# Altium schematic sheet Wifi.SchDoc
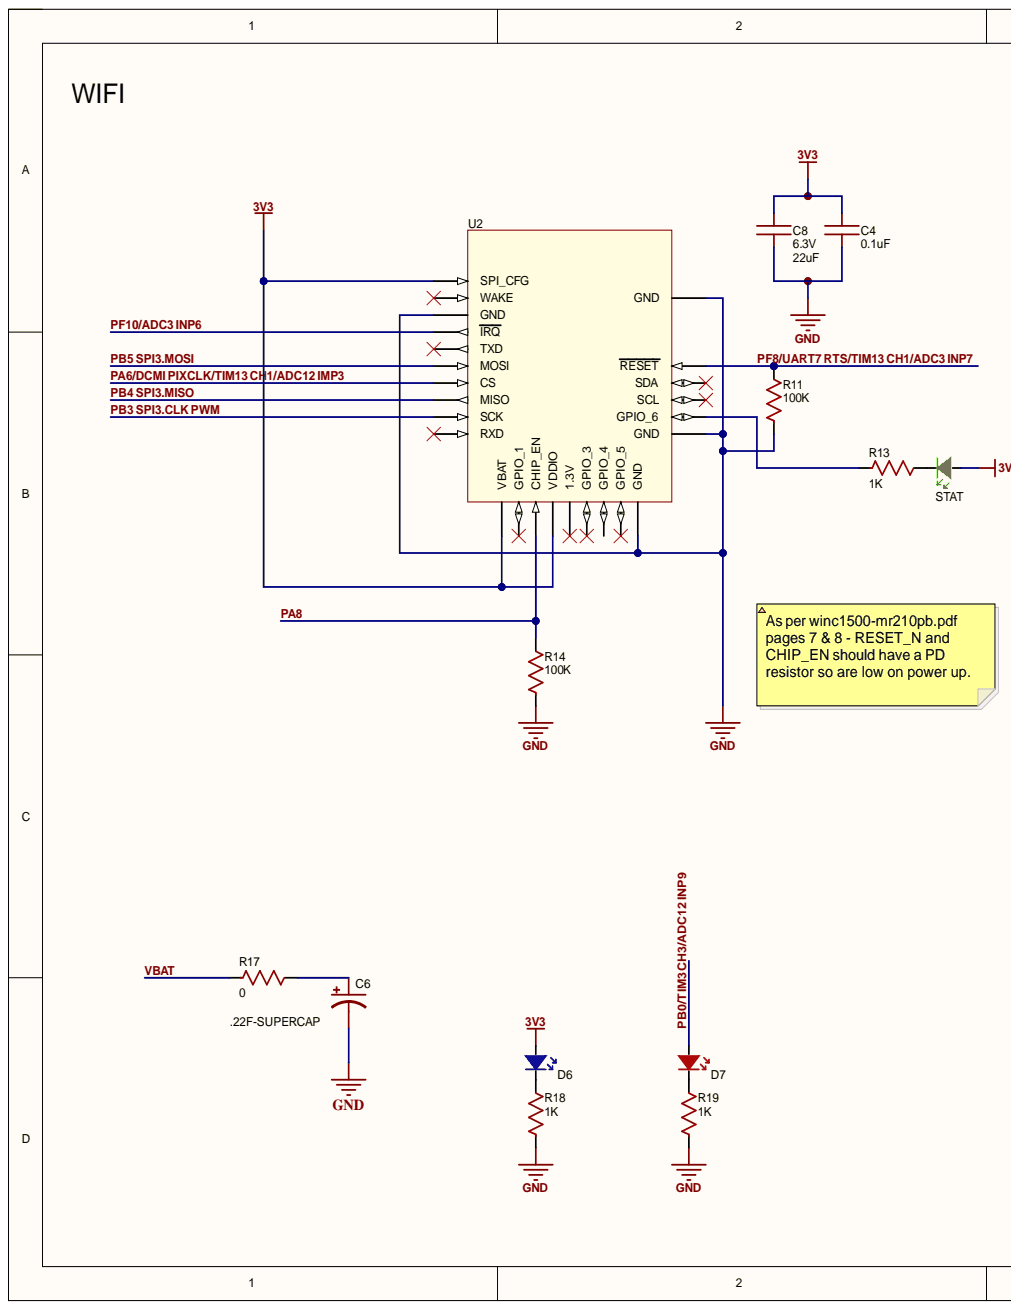
[diagram p0: Wifi.SchDoc, left]
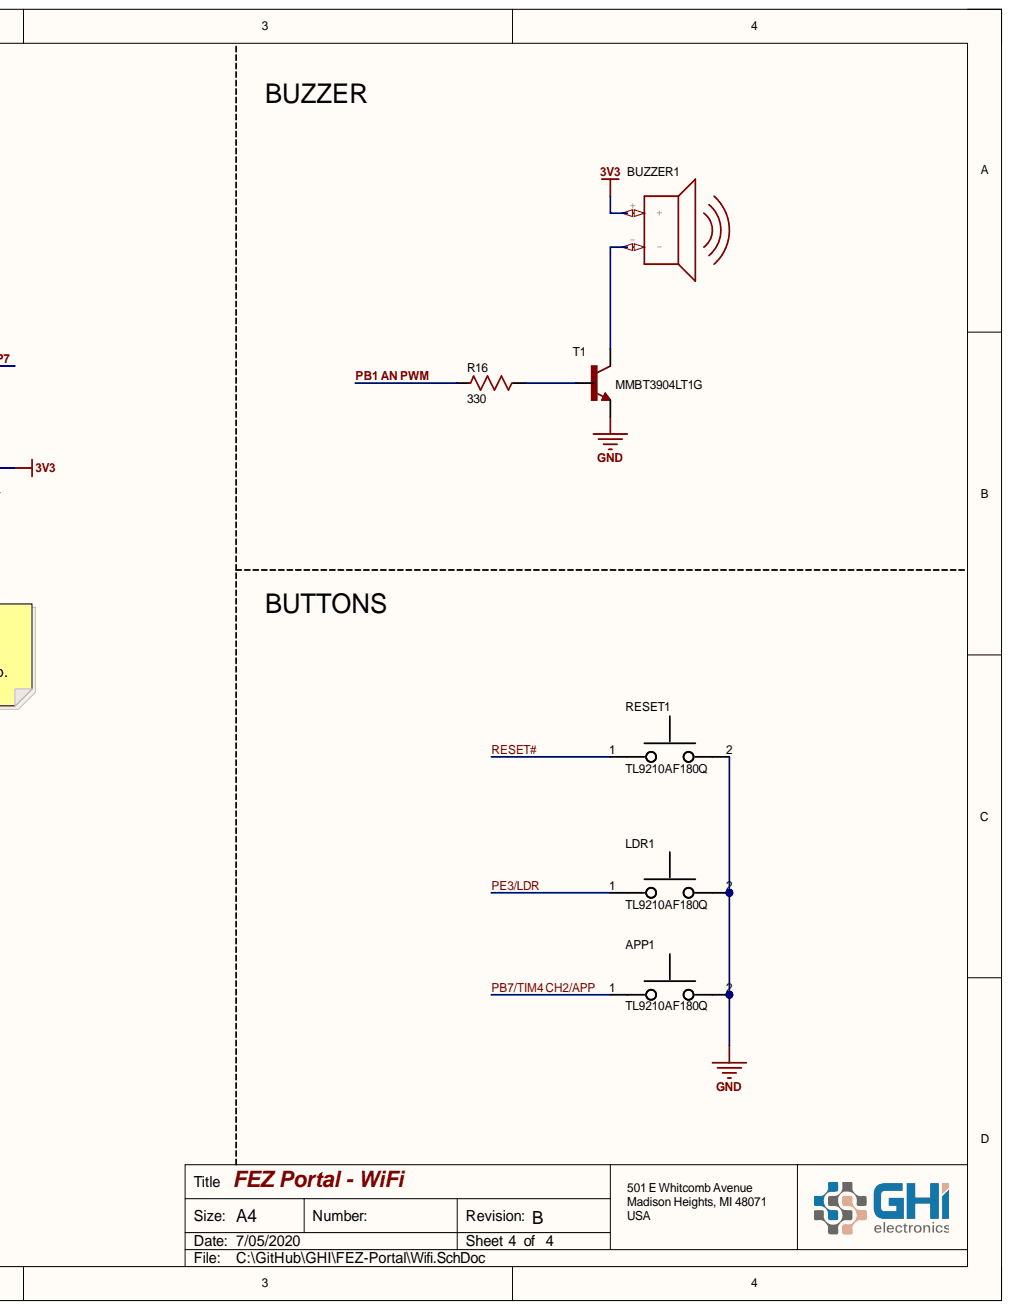
[diagram p1: Wifi.SchDoc, right]

## Parts
APP1  value TL9210AF180Q  Mfr.Part #: TL9210AF180Q  [Button - TL9210]  (hidden comment: Tactile Switch)
BUZZER1  Buzzer - Small  [KLJ-SMD-BUZZER]  (value not drawn)
C4  value 0.1uF  Capacitance: 0.1uF  [C0603 (1608 Metric)]  (hidden comment: 0603 Cap 0.1uF)
C6  .22F-SUPERCAP  [DSK-RADIAL-6.8MM]
C8  value 22uF  Capacitance: 22uF  Voltage: 6.3V  [C0805 L]  (hidden comment: 0805 Cap 22uF)
D6  0603 LED Blue  [0603 LED Blue]  (value not drawn)
D7  0603 LED Red  [0603 LED Red]  (value not drawn)
LDR1  value TL9210AF180Q  Mfr.Part #: TL9210AF180Q  [Button - TL9210]  (hidden comment: Tactile Switch)
R11  value 100K  Resistance: 100K  [R0603 (1608 Metric)]  (hidden comment: 0603 Res 100K)
R13  value 1K  Resistance: 1K  [R0603 (1608 Metric)]  (hidden comment: 0603 1K)
R14  value 100K  Resistance: 100K  [R0603 (1608 Metric)]  (hidden comment: 0603 Res 100K)
R16  value 330  [R0603 (1608 Metric)]  (hidden comment: 0603 330)
R17  value 0  [R0603 (1608 Metric)]  (hidden comment: 0603 Res 0)
R18  value 1K  Resistance: 1K  [R0603 (1608 Metric)]  (hidden comment: 0603 1K)
R19  value 1K  Resistance: 1K  [R0603 (1608 Metric)]  (hidden comment: 0603 1K)
RESET1  value TL9210AF180Q  Mfr.Part #: TL9210AF180Q  [Button - TL9210]  (hidden comment: Tactile Switch)
STAT  0603 LED Green  [0603 LED Green]  (value not drawn)
T1  3904  value MMBT3904LT1G  [SOT23]
U2  ATWINC1500_MR210PB  [ATWINC1500_MR210PA]  (value not drawn)

## Nets
3V3: BUZZER1.+ C4.2 C8.2 D6.2 STAT.2 U2.J10(SPI_CFG) U2.J20(VBAT) U2.J23(VDDIO)
GND: APP1.2 C4.1 C6.- C8.1 LDR1.2 R11.1 R14.1 R18.1 R19.1 RESET1.2 T1.2 U2.J9(GND) U2.J12(GND) U2.J28(GND) U2.J29(GND)
PA6/DCMI PIXCLK/TIM13 CH1/ADC12 IMP3: U2.J16(CS)
PA8: R14.2 U2.J22(CHIP_EN)
PB0/TIM3 CH3/ADC12 INP9: D7.2
PB1 AN PWM: R16.1
PB3 SPI3.CLK PWM: U2.J18(SCK)
PB4 SPI3.MISO: U2.J17(MISO)
PB5 SPI3.MOSI: U2.J15(MOSI)
PB7/TIM4 CH2/APP: APP1.1
PE3/LDR: LDR1.1
PF8/UART7 RTS/TIM13 CH1/ADC3 INP7: R11.2 U2.J4(RESET)
PF10/ADC3 INP6: U2.J13(IRQ)
RESET#: RESET1.1
VBAT: R17.1
NetBUZZER1_-: BUZZER1.- T1.3
NetC6_+: C6.+ R17.2
NetD6_1: D6.1 R18.2
NetD7_1: D7.1 R19.2
NetR13_1: R13.1 U2.J1(GPIO_6)
NetR13_2: R13.2 STAT.1
NetR16_2: R16.2 T1.1

Not connected: U2 J2(SCL) J3(SDA) J11(WAKE) J14(TXD) J19(RXD) J21(GPIO_1) J24(1.3V) J25(GPIO_3) J26(GPIO_4) J27(GPIO_5)

## Library data of the parts
APP1 LDR1 RESET1 = Tactile Switch: SPST 50mA @ 12VDC Top Actuated Plunger for Cap SMD,6x6mm Tactile Switches RoHS; Manufacturer: E-Switch; Component Kind: Standard; LCSC Part #: C273538; Package: SMD,6x6mm; Library Name: GHI.SchLib
BUZZER1 = KLJ-8530-5027: Piezo Speaker from CHANGZHOU KELIKING ELECTRONICS CO., LTD; Component Kind: Standard; PartNo: KLJ-8530-5027; Value: KLJ-8530-5027; Library Name: GHI.SchLib
C4 = 0603 Cap 0.1uF: 0.1uF ±5% 50V X7R 0603 Multilayer Ceramic Capacitors MLCC - SMD/SMT RoHS; Mfr.Part #: CL10B104JB8NNNC; Category: Ceramic; Component Kind: Standard; Digikey: 1276-1033-1-ND; LCSC Part #: C24452; Package: 0603; Tolerance: ±5%; Voltage: 50V; Library Name: GHI-Passives.SchLib
C6 = .22F-SUPERCAP: ELNA DSK-621 - 220mF Electric Double Layer Capacitor (EDLC/SuperCapacitor)    A 220 mF SuperCapacitor. Used for backup power on devices with low standby current; PartNo: DSK-3R3H224U-HL; GateName_1: G$1; SymbolName_1: CAP_POL; Value: DSK-3R3H224U-HL; Component Kind: Standard; DeviceSetName: .22F-SUPERCAP; LibraryName: SparkFun-Capacitors; Library Name: GHI.SchLib
C8 = 0805 Cap 22uF: 22uF ±20% 6.3V X5R 0805 Multilayer Ceramic Capacitors MLCC - SMD/SMT RoHS; Mfr.Part #: CL21A226MQCLRNC; Category: Ceramic; Component Kind: Standard; LCSC Part #: C307530; Package: 0805; Tolerance: ±20%; Library Name: GHI-Passives.SchLib
D6 = 0603 LED Blue: Blue 468nm 0603 Light Emitting Diodes (LED) RoHS; Manufacturer: Lite-On; Mfr.Part #: LTST-C193TBKT-5A; Color: Blue; Component Kind: Standard; LCSC Part #: C125103; Package: 0603; Value: 0603 LED Blue; Library Name: GHI-Opto.SchLib
D7 = 0603 LED Red: Red 631nm 0603 Light Emitting Diodes (LED) RoHS; Manufacturer: Lite-On; Mfr.Part #: LTST-C193KRKT-5A; Color: Red; Component Kind: Standard; LCSC Part #: C157741; Package: 0603; Value: 0603 LED Red; Library Name: GHI-Opto.SchLib
R11 R14 = 0603 Res 100K: 100K ±1% 1/10W ±100ppm/℃ 0603 Chip Resistor - Surface Mount RoHS; Manufacturer: YAGEO; Mfr.Part #: RC0603FR-07100KL; Voltage Rating: *V; Component Kind: Standard; LCSC Part #: C14675; Package: 0603; Power Rating: 1/10W; Resistance Tolerance: ±1%; Library Name: GHI-Passives.SchLib
R13 R18 R19 = 0603 Res 1K: 1K ±5% 1/10W ±100ppm/℃ 0603 Chip Resistor - Surface Mount RoHS; Manufacturer: Walsin Tech Corp; Mfr.Part #: WR06X102 JTL; Voltage Rating: *V; Component Kind: Standard; LCSC Part #: C384295; Package: 0603; Power Rating: 1/10W; Resistance Tolerance: ±5%; Library Name: GHI-Passives.SchLib
R16 = 0603 Res 330: 330 ±5% 1/10W ±100ppm/℃ 0603 Chip Resistor - Surface Mount RoHS; Manufacturer: Walsin Tech Corp; Mfr.Part #: WR06X331 JTL; Voltage Rating: *V; Component Kind: Standard; LCSC Part #: C384242; Package: 0603; Power Rating: 1/10W; Resistance: 330; Resistance Tolerance: ±5%; Library Name: GHI-Passives.SchLib
R17 = 0603 Res 0: ±5% 1/10W 0603 Chip Resistor - Surface Mount RoHS; Manufacturer: YAGEO; Mfr.Part #: RC0603JR-070RL; Voltage Rating: *V; Component Kind: Standard; LCSC Part #: C95177; Package: 0603; Power Rating: 1/10W; Resistance: 0; Resistance Tolerance: ±5%; Library Name: GHI-Passives.SchLib
STAT = 0603 LED Green: Green 567.5~576.5nm 0603 Light Emitting Diodes (LED) RoHS; Manufacturer: Lite-On; Mfr.Part #: LTST-C193KGKT-5A; Color: Green; Component Kind: Standard; LCSC Part #: C125102; Package: 0603; Value: 0603 LED Green; Library Name: GHI-Opto.SchLib
T1 = MMBT3904LT1G: NPN 200mA 40V 300mW SOT-23(SOT-23-3) Transistors (NPN/PNP) RoHS; Manufacturer: ON Semicon; Component Kind: Standard; LCSC Part #: C81464; Mfr.Part #: MMBT3904LT1G; Package: SOT-23(SOT-23-3); Library Name: GHI-Semiconductors.SchLib
U2 = ATWINC1500_MR210PA: ATWINC1500_MR210PA wifi module; PartNo: ATWINC1500-MR210UB1954; Value: ATWINC1500-MR210UB1954; Component Kind: Standard; Library Name: GHI.SchLib
